# Altium schematic sheet Buck.SchDoc
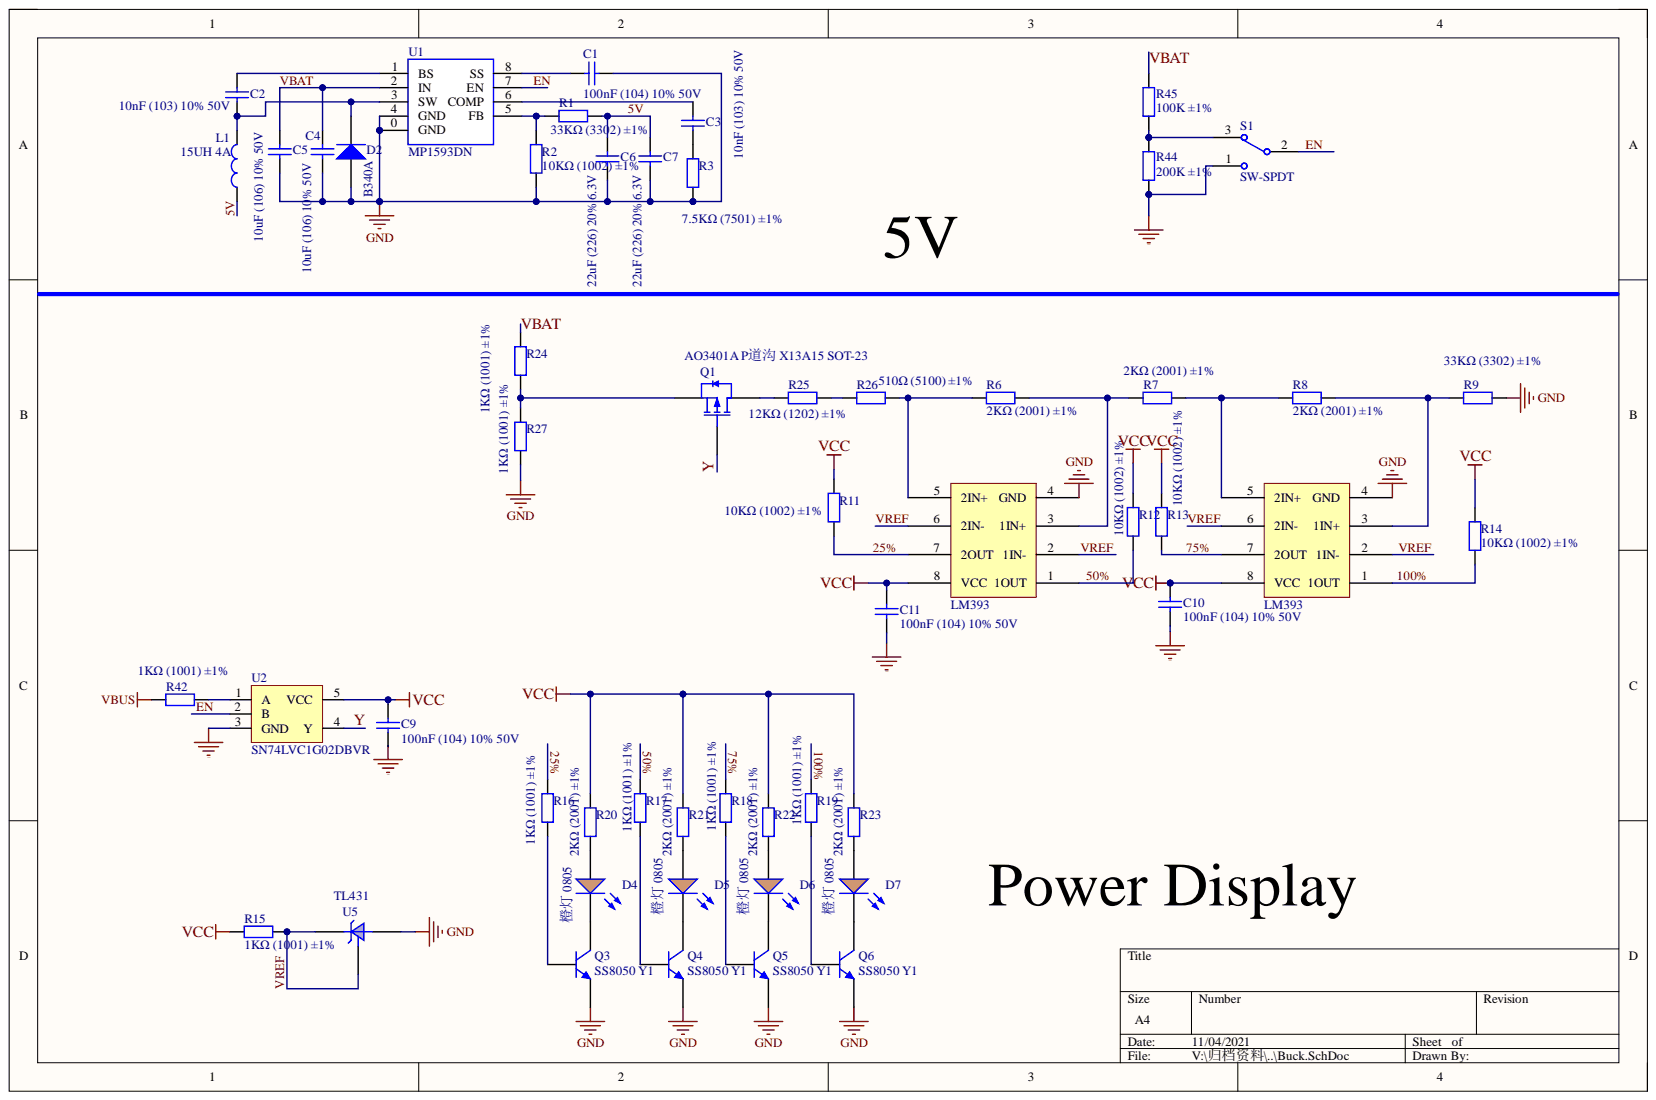
[diagram p0: Buck.SchDoc]

## Parts
C1  100nF (104) 10% 50V  [电容-0603]
C2  10nF (103) 10% 50V  [电容-0603]
C3  10nF (103) 10% 50V  [电容-0603]
C4  10uF (106) 10% 50V  [电容-1206]
C5  10uF (106) 10% 50V  [电容-1206]
C6  22uF (226) 20% 6.3V  [电容-0603]
C7  22uF (226) 20% 6.3V  [电容-0603]
C9  100nF (104) 10% 50V  [电容-0603]
C10  100nF (104) 10% 50V  [电容-0603]
C11  100nF (104) 10% 50V  [电容-0603]
D2  B340A  [SMA(DO-214AC)_S1]
D4  橙灯 0805  Package: 0805  [0805_LED_S1]
D5  橙灯 0805  Package: 0805  [0805_LED_S1]
D6  橙灯 0805  Package: 0805  [0805_LED_S1]
D7  橙灯 0805  Package: 0805  [0805_LED_S1]
L1  15UH 4A  [CD104R]
Q1  AO3401A P道沟 X13A15 SOT-23  [SOT23-3]
Q3  SS8050 Y1  [SOT23-3]
Q4  SS8050 Y1  [SOT23-3]
Q5  SS8050 Y1  [SOT23-3]
Q6  SS8050 Y1  [SOT23-3]
R1  33KΩ (3302) ±1%  [电阻_0603]
R2  10KΩ (1002) ±1%  [电阻_0603]
R3  7.5KΩ (7501) ±1%  [电阻_0603]
R6  2KΩ (2001) ±1%  [电阻_0603]
R7  2KΩ (2001) ±1%  [电阻_0603]
R8  2KΩ (2001) ±1%  [电阻_0603]
R9  33KΩ (3302) ±1%  [电阻_0603]
R11  10KΩ (1002) ±1%  [电阻_0603]
R12  10KΩ (1002) ±1%  [电阻_0603]
R13  10KΩ (1002) ±1%  [电阻_0603]
R14  10KΩ (1002) ±1%  [电阻_0603]
R15  1KΩ (1001) ±1%  [电阻_0603]
R16  1KΩ (1001) ±1%  [电阻_0603]
R17  1KΩ (1001) ±1%  [电阻_0603]
R18  1KΩ (1001) ±1%  [电阻_0603]
R19  1KΩ (1001) ±1%  [电阻_0603]
R20  2KΩ (2001) ±1%  [电阻_0603]
R21  2KΩ (2001) ±1%  [电阻_0603]
R22  2KΩ (2001) ±1%  [电阻_0603]
R23  2KΩ (2001) ±1%  [电阻_0603]
R24  1KΩ (1001) ±1%  [电阻_0603]
R25  12KΩ (1202) ±1%  [电阻_0603]
R26  510Ω (5100) ±1%  [电阻_0603]
R27  1KΩ (1001) ±1%  [电阻_0603]
R42  1KΩ (1001) ±1%  [电阻_0603]
R44  200K ±1%  [电阻_0603]
R45  100K ±1%  [电阻_0603]
S1  SW-SPDT  [SS-12D10，立式]
U1  MP1593DN  [SOIC_8]
U2  SN74LVC1G02DBVR  [SOT23-5]
U3  LM393  [SOP-8]
U4  LM393  [SOP-8]
U5  TL431  [SOT23-3]

## Nets
GND: C1.1 C4.1 C5.1 C6.1 C7.1 C9.2 C10.2 C11.2 D2.1 Q3.2 Q4.2 Q5.2 Q6.2 R2.1 R3.1 R9.2 R27.1 R44.1 S1.1 U1.0(GND) U1.4(GND) U2.3(GND) U3.4(GND) U4.4(GND) U5.3
VBUS: R42.2
VCC: C9.1 C10.1 C11.1 R11.2 R12.2 R13.2 R14.2 R15.2 R20.2 R21.2 R22.2 R23.2 U2.5(VCC) U3.8(VCC) U4.8(VCC)
5V: C6.2 C7.2 L1.2 R1.1
25%: R11.1 R16.2 U3.7(2OUT)
50%: R12.1 R17.2 U3.1(1OUT)
75%: R13.1 R18.2 U4.7(2OUT)
100%: R14.1 R19.2 U4.1(1OUT)
EN: S1.2 U1.7(EN) U2.2(B)
VBAT: C4.2 C5.2 R24.2 R45.2 U1.2(IN)
VREF: R15.1 U3.2(1IN-) U3.6(2IN-) U4.2(1IN-) U4.6(2IN-) U5.1 U5.2
Y: Q1.1 U2.4(Y)
NetC1_2: C1.2 U1.8(SS)
NetC2_1: C2.1 D2.2 L1.1 U1.3(SW)
NetC2_2: C2.2 U1.1(BS)
NetC3_1: C3.1 R3.2
NetC3_2: C3.2 U1.6(COMP)
NetD4_A: D4.A R20.1
NetD4_K: D4.K Q3.3
NetD5_A: D5.A R21.1
NetD5_K: D5.K Q4.3
NetD6_A: D6.A R22.1
NetD6_K: D6.K Q5.3
NetD7_A: D7.A R23.1
NetD7_K: D7.K Q6.3
NetQ1_2: Q1.2 R24.1 R27.2
NetQ1_3: Q1.3 R25.1
NetQ3_1: Q3.1 R16.1
NetQ4_1: Q4.1 R17.1
NetQ5_1: Q5.1 R18.1
NetQ6_1: Q6.1 R19.1
NetR1_2: R1.2 R2.2 U1.5(FB)
NetR6_1: R6.1 R26.2 U3.5(2IN+)
NetR6_2: R6.2 R7.1 U3.3(1IN+)
NetR7_2: R7.2 R8.1 U4.5(2IN+)
NetR8_2: R8.2 R9.1 U4.3(1IN+)
NetR25_2: R25.2 R26.1
NetR42_1: R42.1 U2.1(A)
NetR44_2: R44.2 R45.1 S1.3

## Library data of the parts
C1 C9-C11 = 0603 100nF (104) 10% 50V: 贴片电容; Component Kind: Standard; ComponentLink1Description: 供应商链接; ComponentLink1URL: http://www.szlcsc.com/product/details_15331.html; Library Name: Miscellaneous Devices LC.IntLib; Package: 0603; Supplier: LC; SuppliersPartNumber: C14663
C2 C3 = 0603 10nF (103) 10% 50V: 贴片电容; Component Kind: Standard; ComponentLink1Description: 供应商链接; ComponentLink1URL: http://www.szlcsc.com/product/details_58143.html; Library Name: Miscellaneous Devices LC.IntLib; Package: 0603; Supplier: LC; SuppliersPartNumber: C57112
C4 C5 = 1206 10uF (106) 10% 50V: 贴片电容; Component Kind: Standard; ComponentLink1Description: 供应商链接; ComponentLink1URL: http://www.szlcsc.com/product/details_14236.html; Library Name: Miscellaneous Devices LC.IntLib; Package: 1206; Supplier: LC; SuppliersPartNumber: C13585
C6 C7 = 0603 22uF (226) 20% 6.3V: 贴片电容; Component Kind: Standard; ComponentLink1Description: 供应商链接; ComponentLink1URL: http://www.szlcsc.com/product/details_60514.html; Library Name: Miscellaneous Devices LC.IntLib; Package: 0603; Supplier: LC; SuppliersPartNumber: C59461
D2 = SMA(DO-214AC) SS54: 二极管; Component Kind: Standard; ComponentLink1Description: 供应商链接; ComponentLink1URL: http://www.szlcsc.com/product/details_23178.html; Library Name: Miscellaneous Devices LC.IntLib; Package: SMA(DO-214AC); Supplier: LC; SuppliersPartNumber: C22452
D4-D7 = 0805 橙灯: 发光二极管; Component Kind: Standard; ComponentLink1Description: 供应商链接; ComponentLink1URL: http://www.szlcsc.com/product/details_2669.html; Library Name: Power Board.SchLib; Supplier: LC; SuppliersPartNumber: C2296
L1 = 3.9UH: CL-SLF12575-3R9; Component Kind: Standard; Library Name: 电感、变压器件.SchLib
Q1 = AO3401A P道沟 X13A15 SOT-23: MOS(场效应管); Component Kind: Standard; ComponentLink1Description: 供应商链接; ComponentLink1URL: http://www.szlcsc.com/product/details_15801.html; Library Name: Miscellaneous Devices LC.IntLib; Package: SOT-23; Supplier: LC; SuppliersPartNumber: C15127
Q3-Q6 = SOT-23 SS8050 Y1: 三极管; Component Kind: Standard; ComponentLink1Description: 供应商链接; ComponentLink1URL: http://www.szlcsc.com/product/details_2507.html; Library Name: Miscellaneous Devices LC.IntLib; Package: SOT-23; Supplier: LC; SuppliersPartNumber: C2150
R1 R2 R11-R14 = 0603 10KΩ (1002) 1%: 贴片电阻; Component Kind: Standard; ComponentLink1Description: 供应商链接; ComponentLink1URL: http://www.szlcsc.com/product/details_26547.html; Library Name: Miscellaneous Devices LC.IntLib; Package: 0603; Supplier: LC; SuppliersPartNumber: C25804
R3 = 0603 7.5KΩ (7501) 1%: 贴片电阻; Component Kind: Standard; ComponentLink1Description: 供应商链接; ComponentLink1URL: http://www.szlcsc.com/product/details_23961.html; Library Name: Miscellaneous Devices LC.IntLib; Package: 0603; Supplier: LC; SuppliersPartNumber: C23234
R6-R8 = 0603 2KΩ (2001) 1%: 贴片电阻; Component Kind: Standard; ComponentLink1Description: 供应商链接; ComponentLink1URL: http://www.szlcsc.com/product/details_23702.html; Library Name: Miscellaneous Devices LC.IntLib; Package: 0603; Supplier: LC; SuppliersPartNumber: C22975
R9 = 0603 33KΩ (3302) 1%: 贴片电阻; Component Kind: Standard; ComponentLink1Description: 供应商链接; ComponentLink1URL: http://www.szlcsc.com/product/details_4623.html; Library Name: Miscellaneous Devices LC.IntLib; Package: 0603; Supplier: LC; SuppliersPartNumber: C4216
R15-R19 R24 R27 R42 = 0603 1KΩ (1001) 1%: 贴片电阻; Component Kind: Standard; ComponentLink1Description: 供应商链接; ComponentLink1URL: http://www.szlcsc.com/product/details_21904.html; Library Name: Miscellaneous Devices LC.IntLib; Package: 0603; Supplier: LC; SuppliersPartNumber: C21190
R20-R23 = 0603 220Ω (2200) 1%: 贴片电阻; Component Kind: Standard; ComponentLink1Description: 供应商链接; ComponentLink1URL: http://www.szlcsc.com/product/details_23689.html; Library Name: Miscellaneous Devices LC.IntLib; Package: 0603; Supplier: LC; SuppliersPartNumber: C22962
R25 = 0603 12KΩ (1202) 1%: 贴片电阻; Component Kind: Standard; ComponentLink1Description: 供应商链接; ComponentLink1URL: http://www.szlcsc.com/product/details_23517.html; Library Name: Miscellaneous Devices LC.IntLib; Package: 0603; Supplier: LC; SuppliersPartNumber: C22790
R26 = 0603 510Ω (5100) 1%: 贴片电阻; Component Kind: Standard; ComponentLink1Description: 供应商链接; ComponentLink1URL: http://www.szlcsc.com/product/details_23920.html; Library Name: Miscellaneous Devices LC.IntLib; Package: 0603; Supplier: LC; SuppliersPartNumber: C23193
R44 R45 = R: 贴片电阻; 封装库来自淘宝卖家ID：黄晶宝石: 其他店铺购入均为盗版假冒封装库，请立即申请退款; 联系卖家，免费查找封装: 唯一出售店铺网址https://shop463601444.taobao.com; BOM名称: 贴片电阻; Component Kind: Standard; Library Name: 0.1 - 电阻-电容-电感-磁珠-电位器.SchLib
S1 = SW-SPDT: 拨动开关; Component Kind: Standard; ComponentLink1Description: Manufacturer Link; ComponentLink1URL: http://www.apem.com; DatasheetDocument: 05-Dec-2000; LatestRevisionDate: 17-Dec-2003; LatestRevisionNote: Schematic revised, PCB Footprint replaced.; PackageReference: TL36WW15050; Published: 24-Mar-1999; Library Name: leaf.SchLib; Publisher: Altium Limited
U3 U4 = LM393_8PIN: Component Kind: Standard; Library Name: Integrated_Library.IntLib
U5 = CJ431 0.5%431_[C3113]: 电压基准; Component Kind: Standard; ComponentLink1Description: 供应商链接; ComponentLink1URL: http://www.szlcsc.com/product/details_3500.html; Library Name: Miscellaneous Devices LC.IntLib; Package: SOT-23; Supplier: LC; SuppliersPartNumber: C3113
U1 = MP1593DN: Component Kind: Standard; Description: MP1593DN; Library Name: SunFounder_Library.IntLib
U2 = SN74LVC1G02DBVR: Component Kind: Standard; Description: SN74LVC1G02DBVR; Library Name: Power Board.SchLib
